# Altium schematic sheet Sheet4.SchDoc(Sheet4)
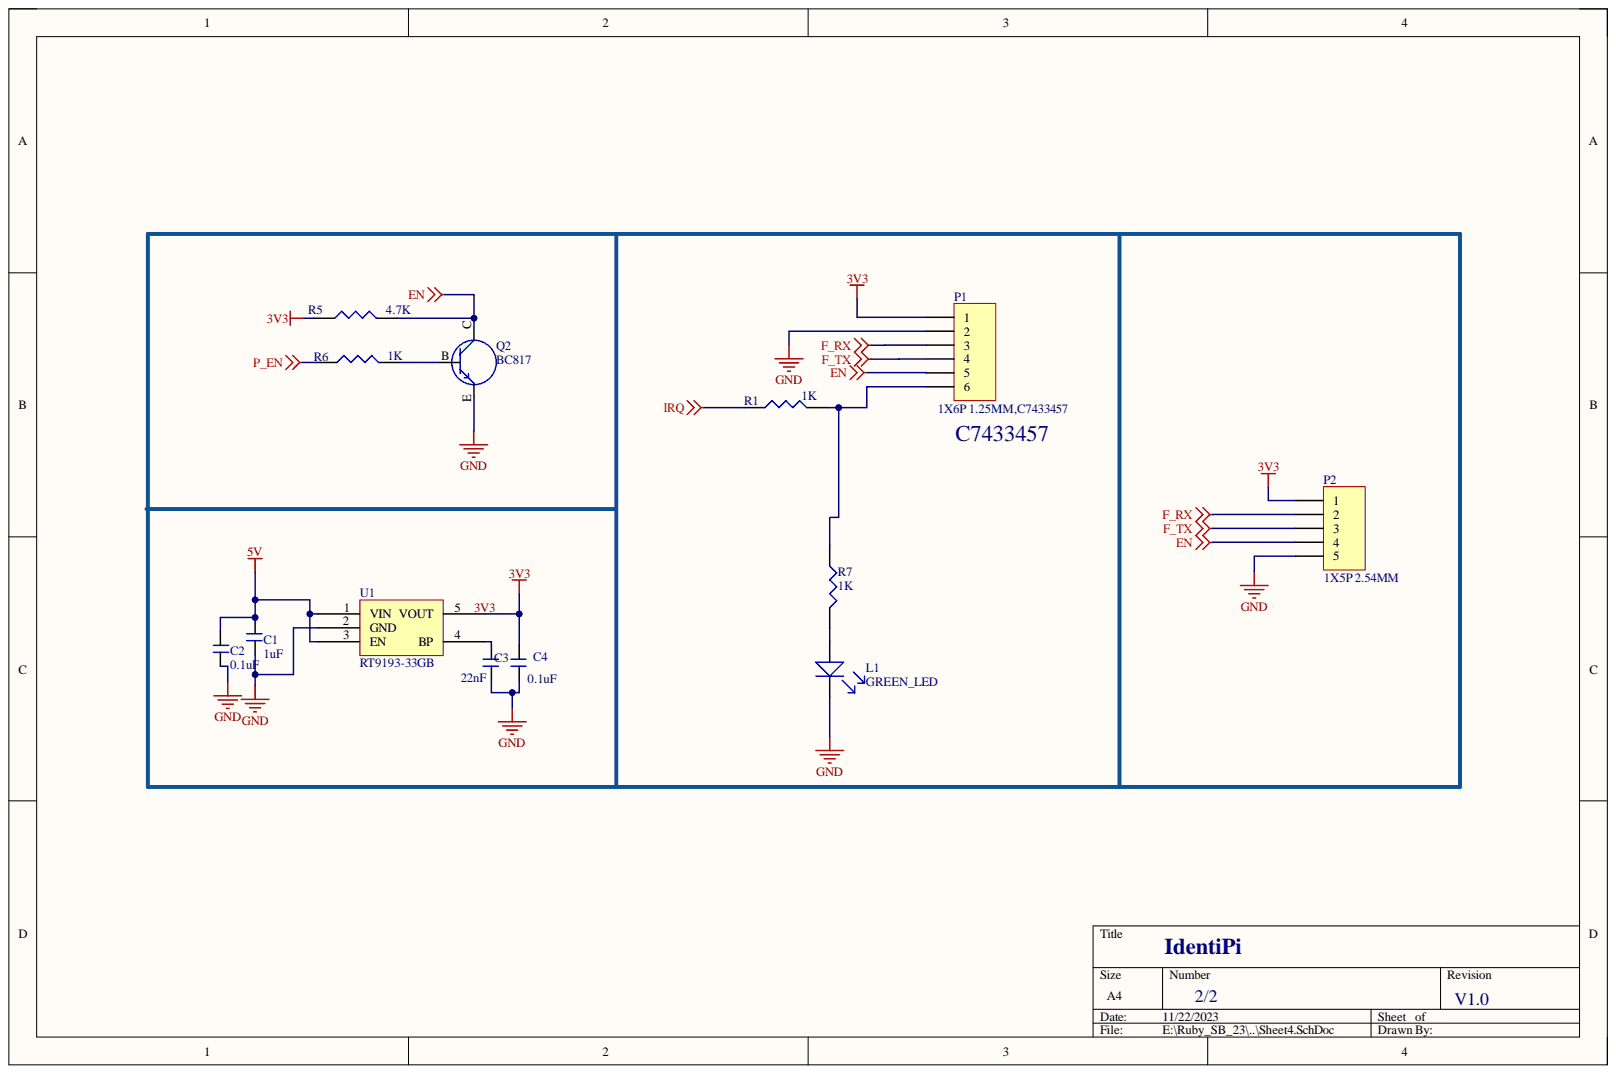
[diagram p0: Sheet4.SchDoc]

## Parts
C1  1uF  [C_0603]
C2  0.1uF  [C_0603]
C3  22nF  [C_0603]
C4  0.1uF  [C_0603]
L1  GREEN_LED  [LED_0603]
P1  1X6P 1.25MM,C7433457  [smd 1x6p p 1.25mm]
P2  1X5P 2.54MM  [5P_TH2.54MM]
Q2  BC817  [SOT23]
R1  1K  [R_0603]
R5  4.7K  [R_0603]
R6  1K  [R_0603]
R7  1K  [R_0603]
U1  RT9193-33GB  [SOT23-5]

## Nets
5V: C1.1 C2.2 U1.1(VIN) U1.3(EN)
EN: P1.5 P2.4 Q2.C R5.2
F_RX: P1.3 P2.2
F_TX: P1.4 P2.3
GND: C1.2 C2.1 C3.2 C4.2 L1.K P1.2 P2.5 Q2.E U1.2(GND)
IRQ: R1.1
P_EN: R6.1
3V3: C4.1 P1.1 P2.1 R5.1 U1.5(VOUT)
NetC3_1: C3.1 U1.4(BP)
NetL1_A: L1.A R7.2
NetP1_6: P1.6 R1.2
NetQ2_B: Q2.B R6.2

Not connected: R7 1

## Library data of the parts
C1-C4 = CAP_NONPOLAR: Component Kind: Standard; Library Name: dxp_pasl_lib.IntLib
L1 = LED: Component Kind: Standard; Library Name: dxp_pasl_lib.IntLib
P1 = Header 6: Header, 6-Pin; Component Kind: Standard; LatestRevisionDate: 17-Jul-2002; LatestRevisionNote: Re-released for DXP Platform.; Library Name: Miscellaneous Connectors.IntLib; Signal Integrity: Connector; Publisher: Altium Limited
P2 = Header 5: Header, 5-Pin; Component Kind: Standard; LatestRevisionDate: 17-Jul-2002; LatestRevisionNote: Re-released for DXP Platform.; Library Name: Miscellaneous Connectors.IntLib; Signal Integrity: Connector; Publisher: Altium Limited
Q2 = TRANSISTER_NPN: Component Kind: Standard; Library Name: dxp_pasl_lib.IntLib
R1 R5-R7 = RES: Component Kind: Standard; Library Name: dxp_pasl_lib.IntLib
U1 = RT9193-33: Component Kind: Standard; Library Name: dxp_pasl_lib.IntLib
